# Altium schematic sheet CW562_DriverBoard_Connectors.SchDoc
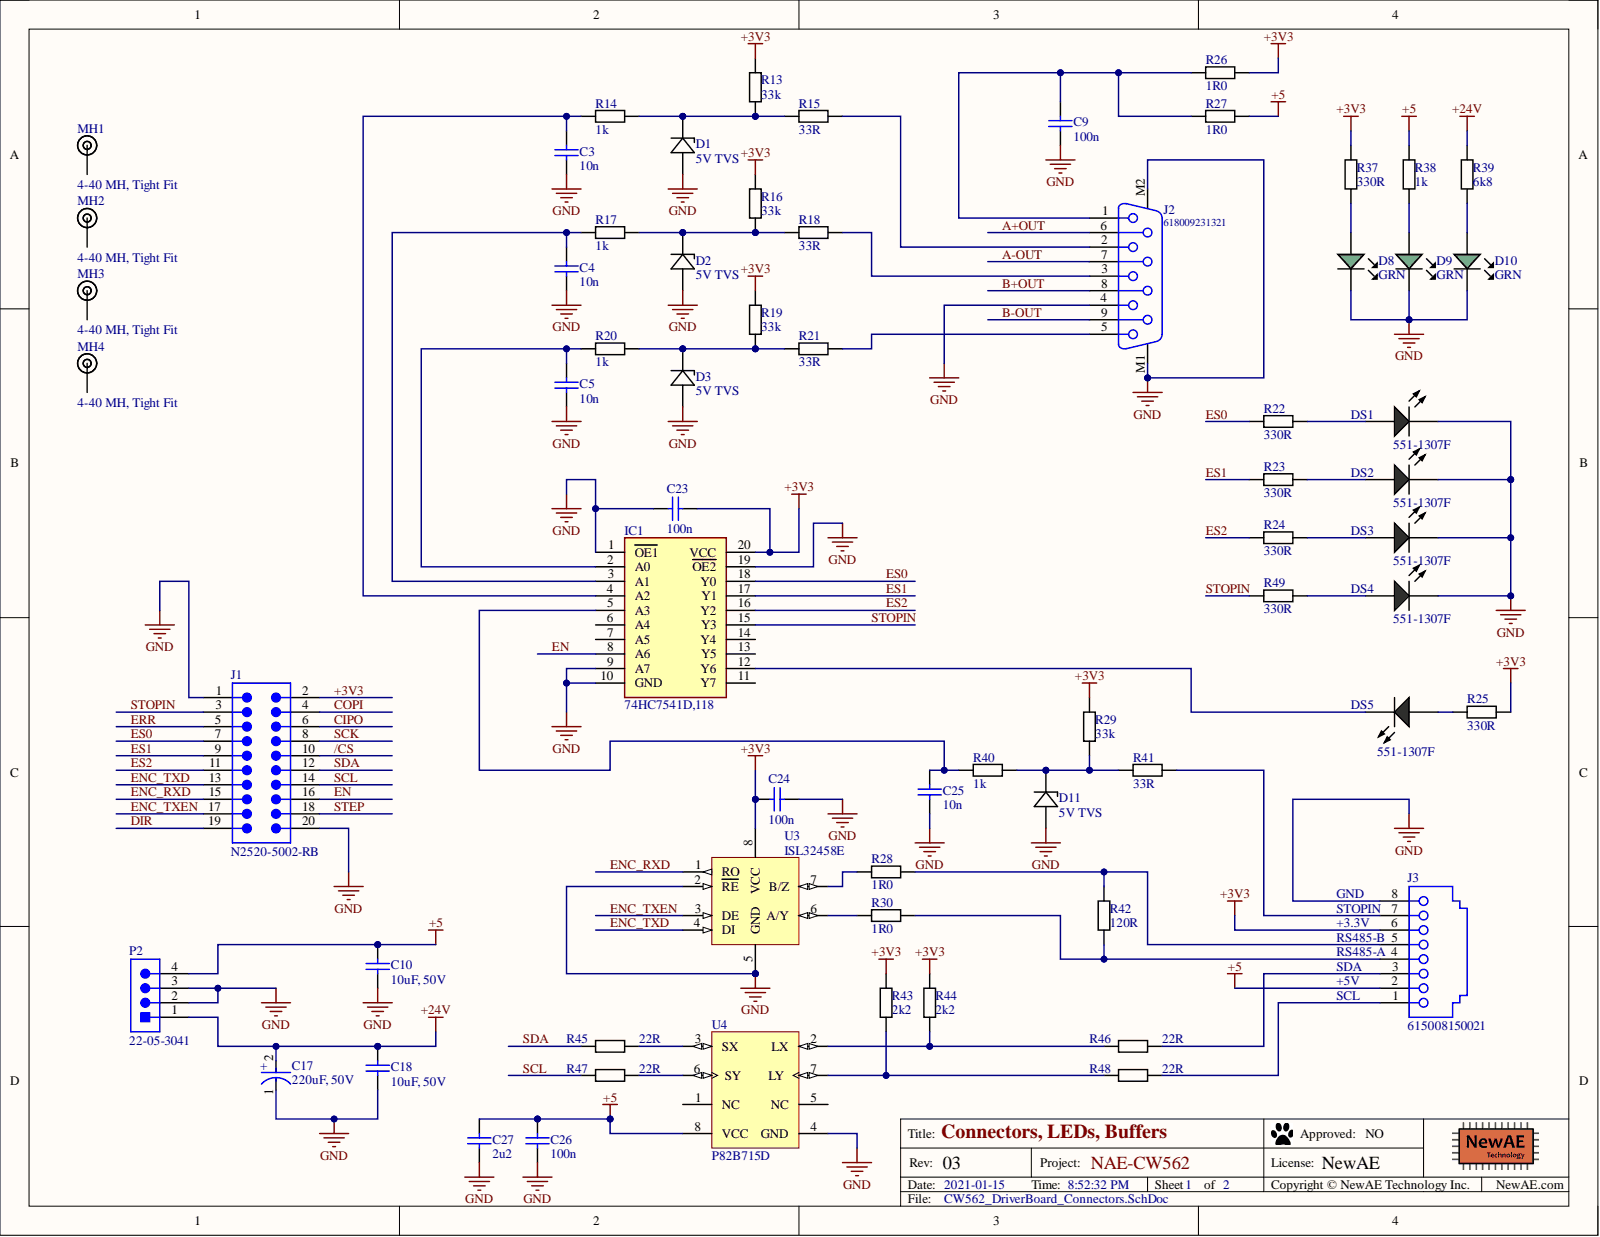
[diagram p0: CW562_DriverBoard_Connectors.SchDoc]

## Parts
C3  10n  [0603]
C4  10n  [0603]
C5  10n  [0603]
C9  100n  [0603]
C10  10uF, 50V  [MURA-1206_H_1.8_M]
C17  220uF, 50V  [FP-FKG-MFG]
C18  10uF, 50V  Voltage Rating DC: 50V  [MURA-1206_H_1.8_M]
C23  100n  [0603]
C24  100n  [0603]
C25  10n  [0603]
C26  100n  [0603]
C27  2u2  [0603]
D1  5V TVS  [SOD-523]
D2  5V TVS  [SOD-523]
D3  5V TVS  [SOD-523]
D8  GRN  [LED-0603-GREEN]
D9  GRN  [LED-0603-GREEN]
D10  GRN  [LED-0603-GREEN]
D11  5V TVS  [SOD-523]
DS1  551-1307F  [DIAL-LED-551-2_V]
DS2  551-1307F  [DIAL-LED-551-2_V]
DS3  551-1307F  [DIAL-LED-551-2_V]
DS4  551-1307F  [DIAL-LED-551-2_V]
DS5  551-1307F  [DIAL-LED-551-2_V]
IC1  74HC7541D,118  [SOIC127P1032X265-20N]
J1  N2520-5002-RB  [20-pin R/A]
J2  618009231321  [618009231321]
J3  615008150021  [615008150021]
MH1  4-40 MH, Tight Fit  [MOUNT_#4_TIGHT]  (mechanical)
MH2  4-40 MH, Tight Fit  [MOUNT_#4_TIGHT]  (mechanical)
MH3  4-40 MH, Tight Fit  [MOUNT_#4_TIGHT]  (mechanical)
MH4  4-40 MH, Tight Fit  [MOUNT_#4_TIGHT]  (mechanical)
P2  22-05-3041  [MOLX-22-05-3041_V]
R13  33k  [0603]
R14  1k  [0603]
R15  33R  [0603]
R16  33k  [0603]
R17  1k  [0603]
R18  33R  [0603]
R19  33k  [0603]
R20  1k  [0603]
R21  33R  [0603]
R22  330R  [0603]
R23  330R  [0603]
R24  330R  [0603]
R25  330R  [0603]
R26  1R0  [0603]
R27  1R0  [0603]
R28  1R0  [0603]
R29  33k  [0603]
R30  1R0  [0603]
R37  330R  [0603]
R38  1k  [0603]
R39  6k8  [0603]
R40  1k  [0603]
R41  33R  [0603]
R42  120R  [0603]
R43  2k2  [0603]
R44  2k2  [0603]
R45  22R  [0603]
R46  22R  [0603]
R47  22R  [0603]
R48  22R  [0603]
R49  330R  [0603]
U3  ISL32458E  [SOIC8]
U4  P82B715D  [D0008A_L]

## Nets
+5: C10.2 C26.2 C27.1 J3.2 P2.4 R27.2 R38.2 U4.8(VCC)
+24V: C17.2 C18.2 P2.1 R39.1
GND: C3.2 C4.2 C5.2 C9.2 C10.1 C17.1 C18.1 C23.1 C24.2 C25.2 C26.1 C27.2 D1.2 D2.2 D3.2 D8.K D9.K D10.K D11.2 DS1.2 DS2.2 DS3.2 DS4.2 IC1.1(OE1) IC1.9(A7) IC1.10(GND) IC1.19(OE2) J1.1 J1.20 J2.4 J2.M1 J2.M2 J3.8 P2.2 P2.3 U3.2(RE) U3.5(GND) U4.4(GND)
+3V3: C23.2 C24.1 IC1.20(VCC) J1.2 J3.6 R13.1 R16.1 R19.1 R25.2 R26.2 R29.1 R37.1 R43.1 R44.1 U3.8(VCC)
/CS: J1.10
A+OUT: J2.6
A-OUT: J2.7
B+OUT: J2.8
B-OUT: J2.9
CIPO: J1.6
COPI: J1.4
DIR: J1.19
EN: IC1.8(A6) J1.16
ENC_RXD: J1.15 U3.1(RO)
ENC_TXD: J1.13 U3.4(DI)
ENC_TXEN: J1.17 U3.3(DE)
ERR: J1.5
ES0: IC1.18(Y0) J1.7 R22.1
ES1: IC1.17(Y1) J1.9 R23.1
ES2: IC1.16(Y2) J1.11 R24.1
SCK: J1.8
SCL: J1.14 R47.1
SDA: J1.12 R45.1
STEP: J1.18
STOPIN: IC1.15(Y3) J1.3 R49.1
NetC3_1: C3.1 IC1.4(A2) R14.1
NetC4_1: C4.1 IC1.3(A1) R17.1
NetC5_1: C5.1 IC1.2(A0) R20.1
NetC9_1: C9.1 J2.1 R26.1 R27.1
NetC25_1: C25.1 IC1.5(A3) R40.1
NetD1_1: D1.1 R13.2 R14.2 R15.1
NetD2_1: D2.1 R16.2 R17.2 R18.1
NetD3_1: D3.1 R19.2 R20.2 R21.1
NetD8_A: D8.A R37.2
NetD9_A: D9.A R38.1
NetD10_A: D10.A R39.2
NetD11_1: D11.1 R29.2 R40.2 R41.1
NetDS1_1: DS1.1 R22.2
NetDS2_1: DS2.1 R23.2
NetDS3_1: DS3.1 R24.2
NetDS4_1: DS4.1 R49.2
NetDS5_1: DS5.1 R25.1
NetDS5_2: DS5.2 IC1.12(Y6)
NetJ2_2: J2.2 R15.2
NetJ2_3: J2.3 R18.2
NetJ2_5: J2.5 R21.2
NetJ3_1: J3.1 R48.2
NetJ3_3: J3.3 R46.2
NetJ3_4: J3.4 R30.2 R42.2
NetJ3_5: J3.5 R28.2 R42.1
NetJ3_7: J3.7 R41.2
NetR28_1: R28.1 U3.7(B/Z)
NetR30_1: R30.1 U3.6(A/Y)
NetR43_2: R43.2 R48.1 U4.7(LY)
NetR44_2: R44.2 R46.1 U4.2(LX)
NetR45_2: R45.2 U4.3(SX)
NetR47_2: R47.2 U4.6(SY)

Not connected: IC1 6(A4) 7(A5) 11(Y7) 13(Y5) 14(Y4); MH1 1; MH2 1; MH3 1; MH4 1; U4 1(NC) 5(NC)

## Library data of the parts
C3-C5 C25 = CCHIP-10n-0603: 10n, 0603; Case-Metric: 1608; Component Group: Capacitor; Database Table Name: main; Case-EIA: 0603; Component Kind: Standard; Component Type: Standard; Library Name: NewAE_AltiumLib.DBLib; Mounting Technology: SMT; Part Number: CCHIP-10n-0603; Pin Count: 2; Supplier 1: Digi-Key; Supplier Part Number 1: 399-1091-1-ND; Value: 10n
C9 C23 C24 C26 = CCHIP-100n-0603: 100n, 0603; Manufacture 1: Kemet; Manufacture Part Number 1: C0603C104K5RACTU; Case-Metric: 1608; Component Group: Capacitor; Database Table Name: main; Rated Voltage: 50VDC; Case-EIA: 0603; Component Kind: Standard; Component Type: Standard; Library Name: NewAE_AltiumLib.DBLib; Mounting Technology: SMT; Part Number: CCHIP-100n-0603; Pin Count: 2; Supplier 1: Digi-Key; Supplier Part Number 1: 399-5089-2-ND; Value: 100n
C10 C18 = CMP-2000-05256-1: CAP, Ceramic, 10 uF, 10%, 50 V, 1206, RoHS, Tape and Reel; Manufacturer: Murata; Brand: MurataElectronics; Case Height: 1.6mm; Case Length: 3.2mm; Dielectric: X5R; Type: GeneralPurposeMLCC; Capacitance: 10uF; Case Code - in: 1206; Case Code - mm: 3216; Case Width: 1.6mm; Component Kind: Standard; Datasheet URL: http://download.siliconexpert.com/pdfs/2015/11/6/15/23/32/328/mrt_/manual/2905595417290350grm31cr61h106ka1223.pdf; Datasheet Version: Nov-15; Factory Pack Quantity: 2000; Library Name: Altium Content Vault; Manufacturer URL: http://www.murata.com/; Maximum Operating Temperature: +85C; Minimum Operating Temperature: -55C; Package / Case: 1206(3216metric); Packaging: Reel; Product: GeneralTypeMLCCs; Product Category: MultilayerCeramicCapacitorsMLCC-SMD/SMT; RoHS: Details; Series: GRM; Termination Style: SMD/SMT; Tolerance: 10%
  C10: Voltage Rating DC: 50V
C17 = CMP-05427-000014-1: CAP ALUM 220UF 20% 50V SMD; Manufacturer: Panasonic; Manufacturer Part Number: EEEFK1H221P; Automotive Grade: Grade 2; Dissipation Factor: 10%; Esr: 0.18Ω; Leakage Current: 110uA; Life: 2000Hours; Material: Aluminum; Ripple Current: 670mA; Automotive: Yes; Capacitance: 220uF; Category: Cap; Component Kind: Standard; ComponentLink2Description: Datasheet; ComponentLink2URL: https://industrial.panasonic.com/cdbs/www-data/pdf/RDE0000/ABA0000C1181.pdf; Device Class L1: Passive Components; Device Class L2: Capacitors; Device Class L3: Aluminum Electrolytic Capacitors; Digikey Description: CAP ALUM 220UF 20% 50V SMD; Height: 10.5mm; Lead Free: Yes; Library Name: Altium Content Vault; Mouser Description: Cap Aluminum Lytic 220uF 50V 20% (10 X 10.2mm) SMD 670mA 2000h 105C Automotive T/R; Octopart: https://octopart.com/eeefk1h221p-panasonic-1332836; Package: FKG; RoHS: TRUE; Temperature: -55°C to +105°C; Temperature Range High: +105°C; Temperature Range Low: -55°C; Tolerance: 20%; Value: 220uF; Voltage Rating: 50V
C27 = CCHIP-2u2-0603: 2u2, 0603; Manufacture 1: Samsung; Manufacture Part Number 1: CL10B225KP8NNNC; Case-Metric: 1608; Component Group: Capacitor; Database Table Name: main; Rated Voltage: 10VDC; Case-EIA: 0603; Component Kind: Standard; Component Type: Standard; Library Name: NewAE_AltiumLib.DBLib; Mounting Technology: SMT; Part Number: CCHIP-2u2-0603; Pin Count: 2; Supplier 1: Digi-Key; Supplier Part Number 1: 1276-1134-2-ND; Value: 2u2
D1-D3 D11 = VESD05B1-02V-G3-08: TVS DIODE 1VSO 5VBD SOD523; Manufacture 1: Vishay; Database Table Name: main; PP Material Stack: 0; Component Group: Diode; Component Kind: Standard; Component Type: Standard; Library Name: NewAE_AltiumLib.DBLib; Manufacture Part Number 1: VESD05B1-02V-G3-08; Mounting Technology: SMT; Part Number: VESD05B1-02V-G3-08; Pin Count: 2; PP Rotation: 0; RoHS: Yes; Supplier 1: Digi-Key; Supplier Part Number 1: VESD05B1-02V-G3-08GICT-ND
D8-D10 = LEDCHIP_0603_GREEN: Chip LED, Green; Manufacture 1: Kingbright; Manufacture Part Number 1: APT1608CGCK; Component Group: Optical; Database Table Name: main; PP Material Stack: 16; PP Rotation: -90; Component Kind: Standard; Component Type: Standard; Library Name: NewAE_AltiumLib.DBLib; Mounting Technology: SMT; Part Number: LEDCHIP_0603_GREEN; Pin Count: 2; Supplier 1: Digi-Key; Supplier Part Number 1: 754-1116-1-ND
DS1-DS5 = CMP-2000-05095-1: 3mm LED Circuit Board Indicator .200' High LED Centerline, GREEN, 1.9 V, 2 mA, 2-Pin THD, RoHS, Bulk; Manufacturer: Dialight; DELETED: Compliant; Emitting Color: YellowGreen; Forward Current: 7mA; Forward Voltage: 2.2V; High Brightness: No; Lens Type: Diffused; Lum Intensity: 1.6mcd; Main Category: StandardLED; Number of Elements: 1; Peak Wavelength: 565nm; Power Dissipation: 20W; Product Depth (mm): 4.32mm; Product Height (mm): 7.11mm; Product Length (mm): 8.89mm; Reverse Voltage: 5V; Shape Type: Circular; Test Current (It): 2mA; Type: Uni-Color; Viewing Angle: 60deg; Component Kind: Standard; Datasheet URL: http://datasheet.octopart.com/551-1307F-Dialight-datasheet-2832.pdf; Datasheet Version: Jul-02; Lens Dimensions: 2.89x2.89x2.54mm; Library Name: Altium Content Vault; Luminous Intensity: 1.6mcd; Manufacturer URL: http://www.dialight.com; Mounting: ThroughHole; Operating Temp Range: -55Cto100C; Operating Temperature Classification: Industrial; Packaging: Bulk; Pin Count: 2; Rad Hardened: No
IC1 = 74HC7541D,118: Integrated Circuit; Manufacturer_Name: Nexperia; Arrow Part Number: 74HC7541D,118; Arrow Price/Stock: https://www.arrow.com/en/products/74hc7541d118/nexperia; Component Kind: Standard; Datasheet Link: https://assets.nexperia.com/documents/data-sheet/74HC_HCT7541.pdf; Height: 2.65mm; Manufacturer_Part_Number: 74HC7541D,118; Mouser Part Number: 771-74HC7541D-T; Mouser Price/Stock: https://www.mouser.co.uk/ProductDetail/Nexperia/74HC7541D118?qs=P62ublwmbi8VXtyI4hHtzA%3D%3D
J1 = NAE-CW-1000-0001-01: 20 Pin R/A Shrouded Header; Manufacture 1: 3M; Component Group: Connector; Database Table Name: main; Pitch: 2.54 mm; Component Kind: Standard; Component Type: Standard; ComponentLink1Description: Datasheet; ComponentLink1URL: https://www.digikey.ca/products/en?keywords=N2520-5002RB; ComponentLink2Description: Package Specification; ComponentLink2URL: http://multimedia.3m.com/mws/media/330367O/3m-four-wall-header-2500-series-ts-0770.pdf; Library Name: NewAE_AltiumLib.DBLib; Manufacture Part Number 1: N2520-5002-RB; Manufacturer: 3M; Mounting Technology: Through-hole; Orientation: Vertical; Part Number: NAE-CW-1000-0001-01; Pin Count: 20; RoHS: True; Supplier 1: Digi-Key; Supplier Part Number 1: MHE20K-ND; Temperature Range: -55 to 125 degC
J2 = CMP-1502-00204-1: Female PCB Connector with Hex Screw WR-DSUB, 10.30 mm, Angled, 9 pins; Manufacturer: Wurth Elektronik; Contact Resistance: 30mOhm; Pin Number: 9; Rated Current: 3A; Working Voltage: 125VAC; Component Kind: Standard; Datasheet URL: https://katalog.we-online.de/em/datasheet/6180xx231321.pdf; Gender: Female; Library Name: Altium Content Vault; Manufacturer URL: http://www.we-online.de; Mounting Technology: THT; Operating Temperature: -40to85degC; Orientation: Angled; Package Reference: 618009231321; Packaging: Tray; Part Number: 618009231321; Publisher: WurthElektronik
J3 = 615008150021: WR-MJ Modular Jack THT Horizontal Mid-Mount Plastic 8P8C Tab Up; Manufacture 1: Wurth Electronics; Manufacturer: Wurth Elektronik; Component Group: Connector; Contact Resistance: 20mOhm; Database Table Name: main; Insulation Resistance: 1000MOhm; PP Material Stack: 0; Rated Current: 1.5A; Withstanding Voltage: 1000VAC; Working Voltage: 125VAC; Component Kind: Standard; Component Type: Standard; ComponentLink1Description: Manufacturer; ComponentLink1URL: http://www.we-online.de; ComponentLink2Description: Datasheet; ComponentLink2URL: https://katalog.we-online.com/em/datasheet/615008150021.pdf; Library Name: NewAE_AltiumLib.DBLib; Manufacture Part Number 1: 615008150021; Manufacturer Part Number: 615008150021; Mounting Technology: THT; Operating Temperature: -40 to +85degC; Packaging: Tray; Part Number: 615008150021; Pin Count: 8; PP Rotation: 0; Published Date: September 2020; Supplier 1: Digi-Key; Type: Horizontal; xPxC: 8P8C
MH1-MH4 = MOUNT_#4_TIGHT: Machine screw 4-40 Mounting Hole; Component Group: Mechanical; Database Table Name: main; PP Material Stack: 0; Component Type: Mechanical; Library Name: NewAE_AltiumLib.DBLib; Part Number: MOUNT_#4_TIGHT; Pin Count: 1; PP Rotation: 0
P2 = CMP-2000-05533-1: Male Header, Pitch 2.54 mm, 1 x 4 Position, Height 6.5 mm, Tail Length 3.58 mm, 0 to 75 degC; Brand: Molex; Current Rating: 4A; Mounting Angle: RightAngle; Pitch: 2.54mm; Voltage Rating: 250V; Component Kind: Standard; Contact Gender: Pin(Male); Contact Material: Brass; Contact Plating: Tin; Datasheet URL: http://www.molex.com/pdm_docs/sd/022053041_sd.pdf; Datasheet Version: Rev. G, 01/2012; Factory Pack Quantity: 12000; Housing Material: Nylon; Library Name: Altium Content Vault; Manufacturer: Molex; Manufacturer URL: http://www.molex.com/; Mounting Style: ThroughHole; Mounting Technology: ThroughHole; Number of Positions: 4Position; Number of Rows: 1Row; Package Description: Male Header, Pitch 2.54 mm, 1 x 4 Position, Height 6.5 mm, Tail Length 3.58 mm; Package Reference: 22-05-3041; Packaging: Bulk; Product: Headers; Product Category: Headers&WireHousings; RoHS: Details; Series: 7478; Termination Style: ThroughHole; Tradename: KK; Type: Locking
R13 R16 R19 R29 = RCHIP-33K-0603: 33k res, 0603, 1%, 1/10W; Manufacture 1: Yageo; Manufacture Part Number 1: RC0603FR-0733KL; Case-Metric: 1608; Component Group: Resistor; Database Table Name: main; Rated Power: 0.1W; Rated Voltage: 75VDC; Case-EIA: 0603; Component Kind: Standard; Component Type: Standard; Library Name: NewAE_AltiumLib.DBLib; Mounting Technology: SMT; Part Number: RCHIP-33K-0603; Pin Count: 2; Supplier 1: Digi-Key; Supplier Part Number 1: 311-33.0KHRCT-ND; Temperature Range: -55C to 155C; Tolerance: 1%; Value: 33k
R14 R17 R20 R38 R40 = RCHIP-1K-0603: 1k res, 0603, 1%, 1/10W; Manufacture 1: Yageo; Manufacture Part Number 1: RC0603FR-071KL; Case-Metric: 1608; Component Group: Resistor; Database Table Name: main; Rated Power: 0.1W; Rated Voltage: 75VDC; Case-EIA: 0603; Component Kind: Standard; Component Type: Standard; Library Name: NewAE_AltiumLib.DBLib; Mounting Technology: SMT; Part Number: RCHIP-1K-0603; Pin Count: 2; Supplier 1: Digi-Key; Supplier Part Number 1: 311-1.00KHRCT-ND; Temperature Range: -55C to 155C; Tolerance: 1%; Value: 1k
R15 R18 R21 R41 = RCHIP-33R-0603: 33R res, 0603, 1%, 1/10W; Manufacture 1: Yageo; Manufacture Part Number 1: RC0603FR-0733RL; Case-Metric: 1608; Component Group: Resistor; Database Table Name: main; Rated Power: 0.1W; Rated Voltage: 75VDC; Case-EIA: 0603; Component Kind: Standard; Component Type: Standard; Library Name: NewAE_AltiumLib.DBLib; Mounting Technology: SMT; Part Number: RCHIP-33R-0603; Pin Count: 2; Supplier 1: Digi-Key; Supplier Part Number 1: 311-33.0HRCT-ND; Temperature Range: -55C to 155C; Tolerance: 1%; Value: 33R
R22-R25 R37 R49 = RCHIP-330R-0603: 330R res, 0603, 1%, 1/10W; Manufacture 1: Yageo; Manufacture Part Number 1: RC0603FR-07330RL; Case-Metric: 1608; Component Group: Resistor; Database Table Name: main; Rated Power: 0.1W; Rated Voltage: 75VDC; Case-EIA: 0603; Component Kind: Standard; Component Type: Standard; Library Name: NewAE_AltiumLib.DBLib; Mounting Technology: SMT; Part Number: RCHIP-330R-0603; Pin Count: 2; Supplier 1: Digi-Key; Supplier Part Number 1: 311-330HRCT-ND; Temperature Range: -55C to 155C; Tolerance: 1%; Value: 330R
R26-R28 R30 = RCHIP-1R0-0603: 1R0 res, 0603, 1%, 1/10W; Manufacture 1: Yageo; Manufacture Part Number 1: RC0603FR-071RL; Case-Metric: 1608; Component Group: Resistor; Database Table Name: main; Rated Power: 0.1W; Rated Voltage: 75VDC; Case-EIA: 0603; Component Kind: Standard; Component Type: Standard; Library Name: NewAE_AltiumLib.DBLib; Mounting Technology: SMT; Part Number: RCHIP-1R0-0603; Pin Count: 2; Supplier 1: Digi-Key; Supplier Part Number 1: 311-1.00HRCT-ND; Temperature Range: -55C to 155C; Tolerance: 1%; Value: 1R0
R39 = RCHIP-6K8-0603: 6k8 res, 0603, 1%, 1/10W; Manufacture 1: Yageo; Manufacture Part Number 1: RC0603FR-076K8L; Case-Metric: 1608; Component Group: Resistor; Database Table Name: main; Rated Power: 0.1W; Rated Voltage: 75VDC; Case-EIA: 0603; Component Kind: Standard; Component Type: Standard; Library Name: NewAE_AltiumLib.DBLib; Mounting Technology: SMT; Part Number: RCHIP-6K8-0603; Pin Count: 2; Supplier 1: Digi-Key; Supplier Part Number 1: 311-6.80KHRCT-ND; Temperature Range: -55C to 155C; Tolerance: 1%; Value: 6k8
R42 = RCHIP-120R-0603: 120R res, 0603, 1%, 1/10W; Manufacture 1: Yageo; Manufacture Part Number 1: RC0603FR-07120RL; Case-Metric: 1608; Component Group: Resistor; Database Table Name: main; Rated Power: 0.1W; Rated Voltage: 75VDC; Case-EIA: 0603; Component Kind: Standard; Component Type: Standard; Library Name: NewAE_AltiumLib.DBLib; Mounting Technology: SMT; Part Number: RCHIP-120R-0603; Pin Count: 2; Supplier 1: Digi-Key; Supplier Part Number 1: 311-120HRCT-ND; Temperature Range: -55C to 155C; Tolerance: 1%; Value: 120R
R43 R44 = RCHIP-2K2-0603: 2k2 res, 0603, 1%, 1/10W; Manufacture 1: Yageo; Manufacture Part Number 1: RC0603FR-072K2L; Case-Metric: 1608; Component Group: Resistor; Database Table Name: main; Rated Power: 0.1W; Rated Voltage: 75VDC; Case-EIA: 0603; Component Kind: Standard; Component Type: Standard; Library Name: NewAE_AltiumLib.DBLib; Mounting Technology: SMT; Part Number: RCHIP-2K2-0603; Pin Count: 2; Supplier 1: Digi-Key; Supplier Part Number 1: 311-2.20KHRCT-ND; Temperature Range: -55C to 155C; Tolerance: 1%; Value: 2k2
R45-R48 = RCHIP-22R-0603: 22R res, 0603, 1%, 1/10W; Manufacture 1: Yageo; Manufacture Part Number 1: RC0603FR-0722RL; Case-Metric: 1608; Component Group: Resistor; Database Table Name: main; Rated Power: 0.1W; Rated Voltage: 75VDC; Case-EIA: 0603; Component Kind: Standard; Component Type: Standard; Library Name: NewAE_AltiumLib.DBLib; Mounting Technology: SMT; Part Number: RCHIP-22R-0603; Pin Count: 2; Supplier 1: Digi-Key; Supplier Part Number 1: 311-22.0HRCT-ND; Temperature Range: -55C to 155C; Tolerance: 1%; Value: 22R
U3 = ISL32458E: IC TXRX RS422/RS485; Manufacture 1: Intersil; Component Group: Digital IC; Database Table Name: main; Component Kind: Standard; Component Type: Standard; Library Name: NewAE_AltiumLib.DBLib; Manufacture Part Number 1: ISL32458E; Mounting Technology: SMT; Part Number: ISL32458E; Pin Count: 8; RoHS: Yes; Supplier 1: Digi-Key; Supplier Part Number 1: ISL32458EIBZ-T7ACT-ND
U4 = CMP-0314-00136-2: I2C Bus Extender, 2 Channel Width, 3.0 to 12.0 V, -40 to 85 degC, 8-pin SOIC (D), Green (RoHS & no Sb/Br); Manufacturer: Texas Instruments; 5V Tolerant I/O: Yes; Channel Width: 2; Master Side I2C Bus Capacitance Supported(pF): 400; SubFamily: I2C Hub, Buffer, Repeater; VCC(Max)(V): 12; VCC(Min)(V): 3; Code_JEDEC: MS-012AA; Component Kind: Standard; ComponentLink1Description: Datasheet; ComponentLink1URL: http://www.ti.com/general/docs/lit/getliterature.tsp?genericPartNumber=P82B715&fileType=pdf; DatasheetVersion: SCPS145A; Eco-Plan: Green (RoHS & no Sb/Br); Library Name: Altium Content Vault; Max Frequency(kHz): 400; Mounting Technology: Surface Mount; Open Drain I/O Type: Yes; PackageDescription: 8-Pin Plastic Small Outline Integrated Circuit, 4.9 x 3.9 mm, Pitch 1.27 mm Pitch; PackageReference: D0008A; PackageVersion: revM, Jun-2011; PartNumber: P82B715D; Slave Side I2C Bus Capacitance Supported(pF): 400; Vcc range(V): 3.0 to 12.0
